# Altium schematic sheet Sheet1.SchDoc
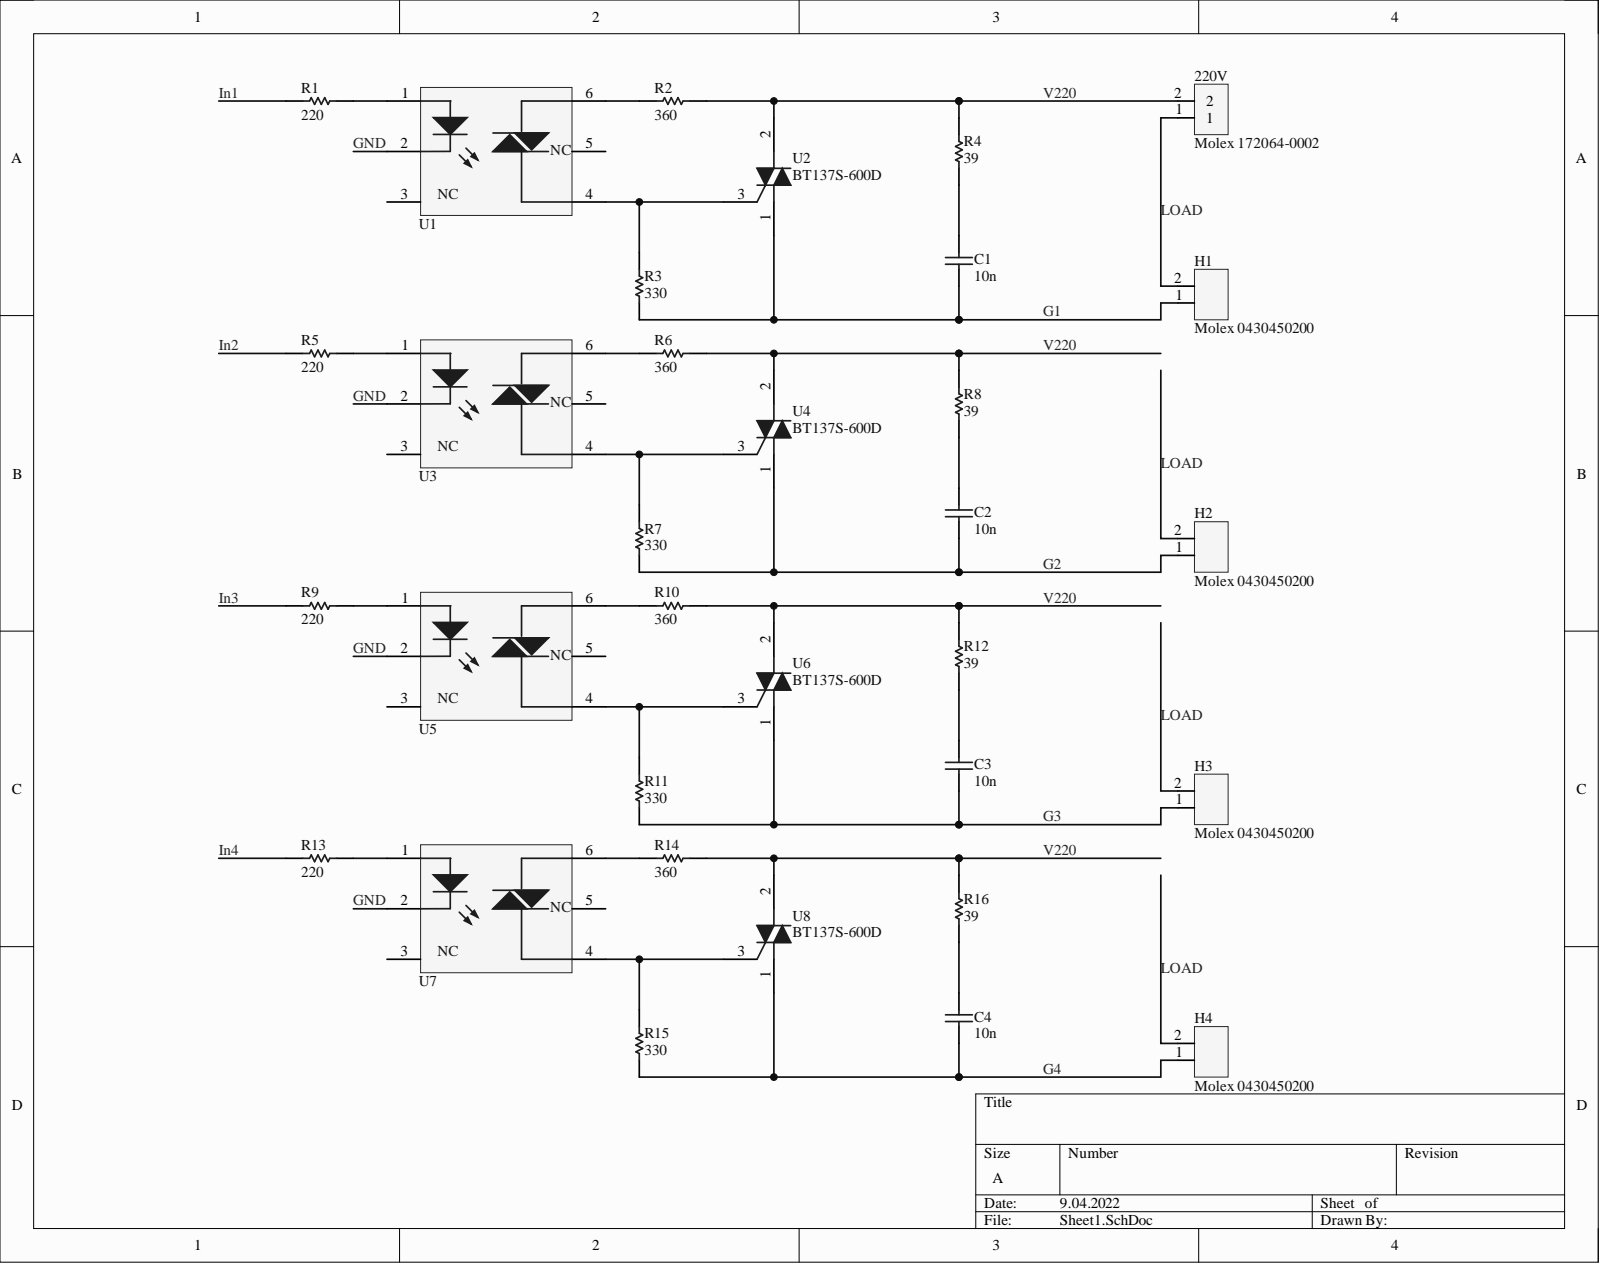
[diagram p0: Sheet1.SchDoc]

## Parts
220V  Molex 172064-0002  Manufacturer Part Number: 172064-0002  [PCB-2otei3o5k5ejq30r2ry3-1]
C1  10n  [PCB-vcjr8nxpwfuh09uwlcd6-1]
C2  10n  [PCB-vcjr8nxpwfuh09uwlcd6-1]
C3  10n  [PCB-vcjr8nxpwfuh09uwlcd6-1]
C4  10n  [PCB-vcjr8nxpwfuh09uwlcd6-1]
H1  Molex 0430450200  Manufacturer Part Number: 0430450200  [PCB-lpc9z9t8uewl5xeystzy-1]
H2  Molex 0430450200  Manufacturer Part Number: 0430450200  [PCB-lpc9z9t8uewl5xeystzy-1]
H3  Molex 0430450200  Manufacturer Part Number: 0430450200  [PCB-lpc9z9t8uewl5xeystzy-1]
H4  Molex 0430450200  Manufacturer Part Number: 0430450200  [PCB-lpc9z9t8uewl5xeystzy-1]
R1  220  [RESC2013X50X30ML20T20]
R2  360  [RESC2013X50X30ML20T20]
R3  330  [RESC2013X50X30ML20T20]
R4  39  [RESC2013X50X30ML20T20]
R5  220  [RESC2013X50X30ML20T20]
R6  360  [RESC2013X50X30ML20T20]
R7  330  [RESC2013X50X30ML20T20]
R8  39  [RESC2013X50X30ML20T20]
R9  220  [RESC2013X50X30ML20T20]
R10  360  [RESC2013X50X30ML20T20]
R11  330  [RESC2013X50X30ML20T20]
R12  39  [RESC2013X50X30ML20T20]
R13  220  [RESC2013X50X30ML20T20]
R14  360  [RESC2013X50X30ML20T20]
R15  330  [RESC2013X50X30ML20T20]
R16  39  [RESC2013X50X30ML20T20]
U1  MOC3043  [PCB-723iinp4b8wdj569zabb-1]  (value not drawn)
U2  BT137S-600D  [PCB-lydts9a3iyc23ocvkdlb-1]
U3  MOC3043  [PCB-723iinp4b8wdj569zabb-1]  (value not drawn)
U4  BT137S-600D  [PCB-lydts9a3iyc23ocvkdlb-1]
U5  MOC3043  [PCB-723iinp4b8wdj569zabb-1]  (value not drawn)
U6  BT137S-600D  [PCB-lydts9a3iyc23ocvkdlb-1]
U7  MOC3043  [PCB-723iinp4b8wdj569zabb-1]  (value not drawn)
U8  BT137S-600D  [PCB-lydts9a3iyc23ocvkdlb-1]

## Nets
G1: C1.1 H1.1 R3.1 U2.1
G2: C2.1 H2.1 R7.1 U4.1
G3: C3.1 H3.1 R11.1 U6.1
G4: C4.1 H4.1 R15.1 U8.1
GND: U1.2 U3.2 U5.2 U7.2
In1: R1.1
In2: R5.1
In3: R9.1
In4: R13.1
LOAD: 220V.1 H1.2 H2.2 H3.2 H4.2
V220: 220V.2 R2.2 R4.2 R6.2 R8.2 R10.2 R12.2 R14.2 R16.2 U2.2 U4.2 U6.2 U8.2
NetC1_2: C1.2 R4.1
NetC2_2: C2.2 R8.1
NetC3_2: C3.2 R12.1
NetC4_2: C4.2 R16.1
NetR1_2: R1.2 U1.1
NetR2_1: R2.1 U1.6
NetR3_2: R3.2 U1.4 U2.3
NetR5_2: R5.2 U3.1
NetR6_1: R6.1 U3.6
NetR7_2: R7.2 U3.4 U4.3
NetR9_2: R9.2 U5.1
NetR10_1: R10.1 U5.6
NetR11_2: R11.2 U5.4 U6.3
NetR13_2: R13.2 U7.1
NetR14_1: R14.1 U7.6
NetR15_2: R15.2 U7.4 U8.3

Not connected: U1 3 5(NC); U3 3 5(NC); U5 3 5(NC); U7 3 5(NC)

## Library data of the parts
220V = CMP-340ffcf222ebebc8-1: 5.70mm Pitch, Mega-Fit® Right-Angle Header, 2 Circuits, 381µm Gold (Au) Plating, UL 94 V-0, Tray; Gender: Male; Glow Wire Compliant: Compliant; Number of Circuits: 2; Color: Black; Component Kind: Standard; Contact Material: Copper; Contact Plating: Gold; Flammability Rating: UL 94 V-0; Halogen Free Status: Low Halogen; Library Name: CommunityVault; Lifecycle Status: Active; Mounting Style: Through Hole; Number of Contacts: 2; Octopart URL: http://octopart.com/172064-0002-molex-32977794; OctopartID: 340ffcf222ebebc8; Orientation: Right Angle; RoHS: Compliant
C1-C4 = CMP-526e9b1a13078da9-2: CL21 Series 10 nF 50V ±10 % Tolerance X7R SMT Multilayer Ceramic Capacitor; Manufacturer Part Number: CL21B103KBANNNC; Capacitance: 1e-08 F; Capacitance Tolerance: ±10%; Lead-Free Status: Lead Free; Voltage Rating (DC): 50.0 V; Case/Package: 0805; Component Kind: Standard; Dielectric Characteristic: X7R; Library Name: CommunityVault; Mounting Style: Surface Mount; Octopart URL: http://octopart.com/cl21b103kbannnc-samsung-8283151; OctopartID: 526e9b1a13078da9; RoHS: Compliant
H1-H4 = CMP-b9e170746c1a6626-2: Conn Wire to Board HDR 2 POS Solder RA Thru-Hole Micro-Fit 3.0Ã¢âÂ¢ Tray; Component Kind: Standard; Contact Plating: Tin; Flammability Rating: UL 94 V-0; Library Name: CommunityVault; Lifecycle Status: Not Listed by Manufacturer; Octopart URL: http://octopart.com/0430450200-molex-10481821; OctopartID: b9e170746c1a6626; Orientation: Right Angle; RoHS: Compliant
R1-R16 = CMP-1857726-2: Res Thick Film 0805 100K Ohm 1% 1/8W ±100ppm/C Molded SMD Paper T/R; Case/Package (SI): 2012; Lead-Free Status: Lead Free; Power Rating: 0.125 W; Resistance: 100000.0 Ω; Temperature Coefficient: 100.0 ppm/°C; Voltage Rating (DC): 150.0 V; Case/Package: 0805; Component Kind: Standard; Library Name: CommunityVault; Mounting Style: Surface Mount; Number of Pins: 2; Octopart Url: https://octopart.com/crcw0805100kfkea-vishay-39456141; OctopartID: 6231a9614adabdf6; REACH SVHC Compliance: No SVHC; Resistance Tolerance: ±1%; RoHS: Compliant; Size-Height: 0.00045 m; Size-Length: 0.002 m; Size-Width: 0.00125 m
U1 U3 U5 U7 = CMP-2a1be31bc7c9f5dc-2: OPTOCOUPLER, TRANSISTOR O/P; No. of Channels: 1; Voltage, Isolation: 5300V; Output Type: Transistor; Input Current: 6...; Manufacturer Part Number: 4N25SR2VM; Lead-Free Status: Lead Free; Number of Channels: 1; Output Voltage: V; Rise Time: 2e-06 s; Input Current: 0.01 A; Input Voltage (DC): 1.18 V; Isolation Voltage: 5300.0 V; Component Kind: Standard; Library Name: CommunityVault; Lifecycle Status: Active; Mounting Style: Surface Mount; Number of Circuits: 1; Number of Pins: 6; Octopart URL: http://octopart.com/4n25sr2vm-fairchild+semiconductor-706740; OctopartID: 2a1be31bc7c9f5dc; Packaging: Tape & Reel (TR); REACH SVHC Compliance: No SVHC; RoHS: Compliant
U2 U4 U6 U8 = CMP-a42a6dbf3fa07bed-1: Thyristor TRIAC 600V 71A 3-Pin(2+Tab) DPAK T/R; Manufacturer Part Number: BT137S-600D,118; Lead-Free Status: Lead Free; Component Kind: Standard; Lifecycle Status: Active; Mounting Style: Surface Mount; Number of Pins: 3; Octopart URL: http://octopart.com/bt137s-600d%2C118-nxp+semiconductors-10469100; OctopartID: a42a6dbf3fa07bed; Packaging: Cut Tape (CT); REACH SVHC Compliance: No SVHC; RoHS: Compliant
  U2: Library Name: FavoritesLibrary
  U4 U6 U8: Library Name: CommunityVault
